# Altium schematic sheet GAP_Power.SchDoc
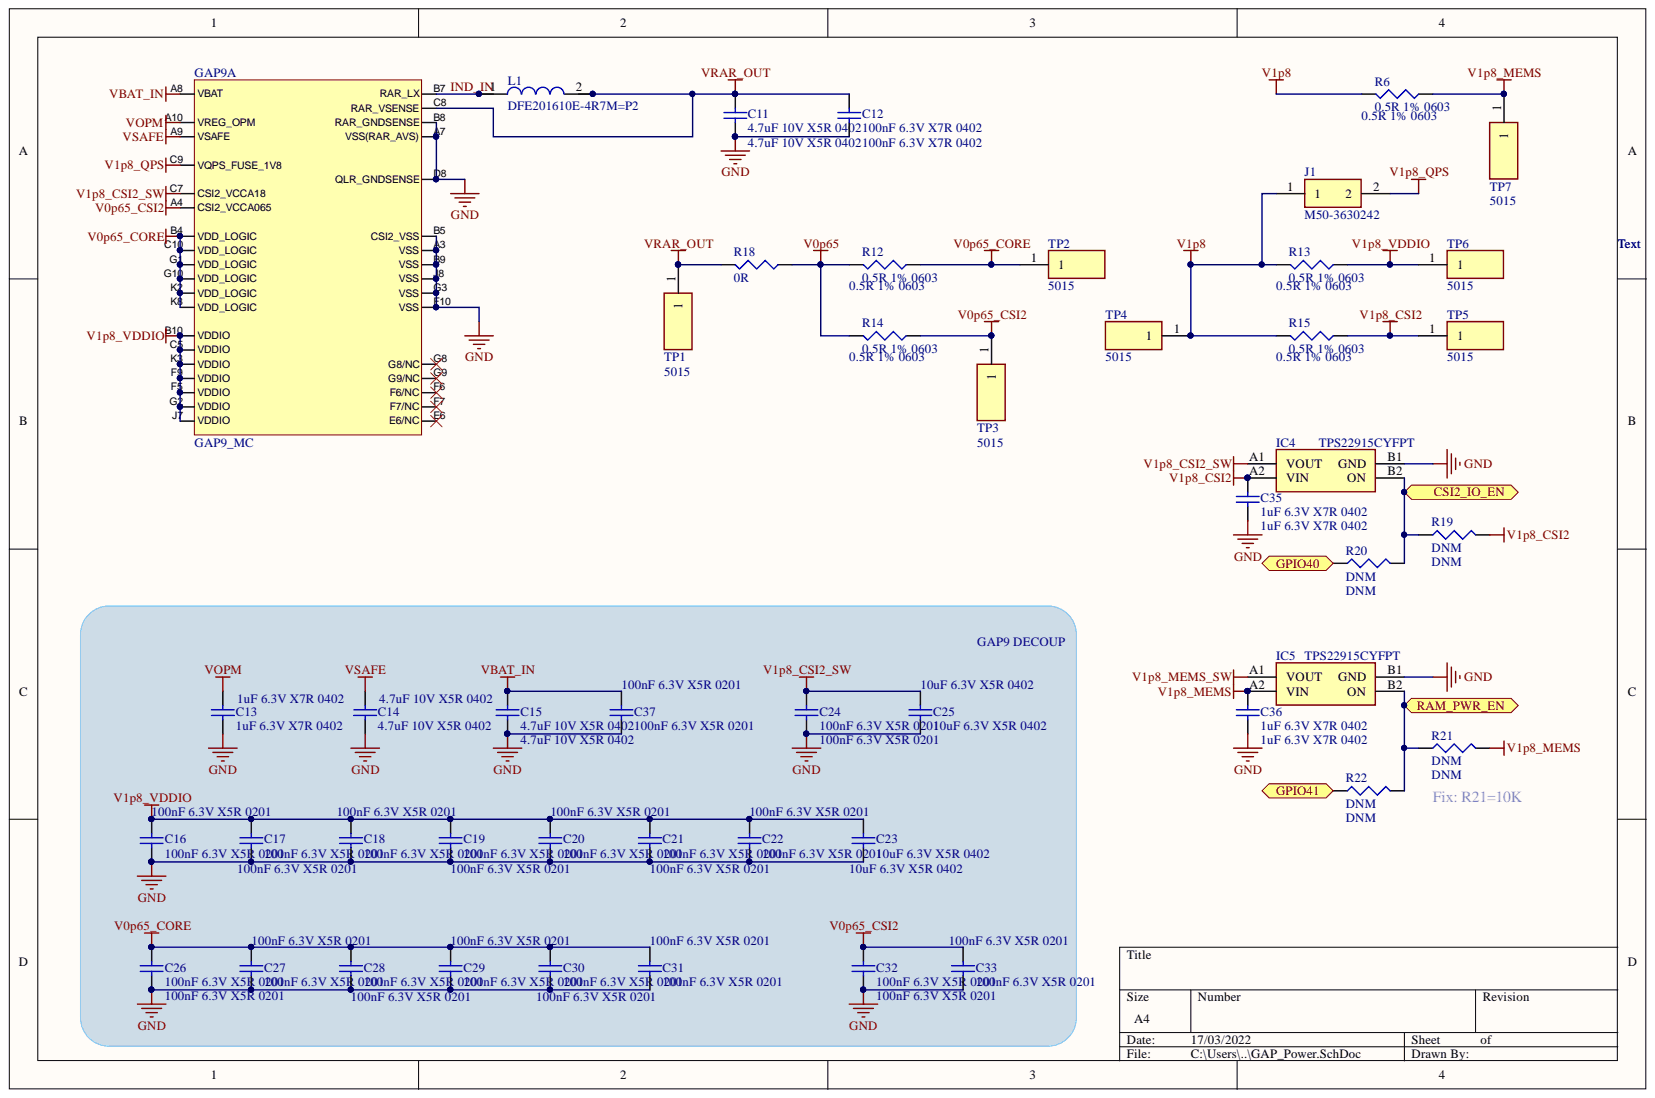
[diagram p0: GAP_Power.SchDoc]

## Parts
C11  4.7uF 10V X5R 0402  [0402]
C12  100nF 6.3V X7R 0402  [0402]
C13  1uF 6.3V X7R 0402  [0402]
C14  4.7uF 10V X5R 0402  [0402]
C15  4.7uF 10V X5R 0402  [0402]
C16  100nF 6.3V X5R 0201  [CAPC0603X33N]
C17  100nF 6.3V X5R 0201  [CAPC0603X33N]
C18  100nF 6.3V X5R 0201  [CAPC0603X33N]
C19  100nF 6.3V X5R 0201  [CAPC0603X33N]
C20  100nF 6.3V X5R 0201  [CAPC0603X33N]
C21  100nF 6.3V X5R 0201  [CAPC0603X33N]
C22  100nF 6.3V X5R 0201  [CAPC0603X33N]
C23  10uF 6.3V X5R 0402  [0402]
C24  100nF 6.3V X5R 0201  [CAPC0603X33N]
C25  10uF 6.3V X5R 0402  [0402]
C26  100nF 6.3V X5R 0201  [CAPC0603X33N]
C27  100nF 6.3V X5R 0201  [CAPC0603X33N]
C28  100nF 6.3V X5R 0201  [CAPC0603X33N]
C29  100nF 6.3V X5R 0201  [CAPC0603X33N]
C30  100nF 6.3V X5R 0201  [CAPC0603X33N]
C31  100nF 6.3V X5R 0201  [CAPC0603X33N]
C32  100nF 6.3V X5R 0201  [CAPC0603X33N]
C33  100nF 6.3V X5R 0201  [CAPC0603X33N]
C35  1uF 6.3V X7R 0402  [0402]
C36  1uF 6.3V X7R 0402  [0402]
C37  100nF 6.3V X5R 0201  [CAPC0603X33N]
GAP9  GAP9_MC  [GAP9]  (part A)
IC4  TPS22915CYFPT  [BGA4C40P2X2_74X74X50]
IC5  TPS22915CYFPT  [BGA4C40P2X2_74X74X50]
J1  M50-3630242  [M503630242]
L1  DFE201610E-4R7M=P2  [1285ASH1R0MP2]
R6  0.5R 1% 0603  [J1-0603]
R12  0.5R 1% 0603  [J1-0603]
R13  0.5R 1% 0603  [J1-0603]
R14  0.5R 1% 0603  [J1-0603]
R15  0.5R 1% 0603  [J1-0603]
R18  0R  [J1-0603]
R19  DNM  [0402]
R20  DNM  [0402]
R21  DNM  [0402]
R22  DNM  [0402]
TP1  5015  [5015]
TP2  5015  [5015]
TP3  5015  [5015]
TP4  5015  [5015]
TP5  5015  [5015]
TP6  5015  [5015]
TP7  5015  [5015]

## Ports
CSI2_IO_EN, GPIO40, GPIO41, RAM_PWR_EN

## Nets
GND: C11.1 C12.1 C13.1 C14.1 C15.1 C16.1 C17.1 C18.1 C19.1 C20.1 C21.1 C22.1 C23.1 C24.1 C25.1 C26.1 C27.1 C28.1 C29.1 C30.1 C31.1 C32.1 C33.1 C35.1 C36.1 C37.1 GAP9.A3(VSS) GAP9.A7(VSS(RAR_AVS)) GAP9.B5(CSI2_VSS) GAP9.B8(RAR_GNDSENSE) GAP9.B9(VSS) GAP9.D8(QLR_GNDSENSE) GAP9.F10(VSS) GAP9.G3(VSS) GAP9.J8(VSS) IC4.B1(GND) IC5.B1(GND)
V0p65: R12.2 R14.2 R18.1
V0p65_CORE: C26.2 C27.2 C28.2 C29.2 C30.2 C31.2 GAP9.B4(VDD_LOGIC) GAP9.C10(VDD_LOGIC) GAP9.G1(VDD_LOGIC) GAP9.G10(VDD_LOGIC) GAP9.K2(VDD_LOGIC) GAP9.K8(VDD_LOGIC) R12.1 TP2.1
V0p65_CSI2: C32.2 C33.2 GAP9.A4(CSI2_VCCA065) R14.1 TP3.1
V1p8: J1.1 R6.2 R13.2 R15.2 TP4.1
V1p8_CSI2: C35.2 IC4.A2(VIN) R15.1 R19.2 TP5.1
V1p8_CSI2_SW: C24.2 C25.2 GAP9.C7(CSI2_VCCA18) IC4.A1(VOUT)
V1p8_MEMS: C36.2 IC5.A2(VIN) R6.1 R21.2 TP7.1
V1p8_MEMS_SW: IC5.A1(VOUT)
V1p8_QPS: GAP9.C9(VQPS_FUSE_1V8) J1.2
V1p8_VDDIO: C16.2 C17.2 C18.2 C19.2 C20.2 C21.2 C22.2 C23.2 GAP9.B10(VDDIO) GAP9.C5(VDDIO) GAP9.F5(VDDIO) GAP9.F9(VDDIO) GAP9.G2(VDDIO) GAP9.J7(VDDIO) GAP9.K3(VDDIO) R13.1 TP6.1
VBAT_IN: C15.2 C37.2 GAP9.A8(VBAT)
VOPM: C13.2 GAP9.A10(VREG_OPM)
VRAR_OUT: C11.2 C12.2 GAP9.C8(RAR_VSENSE) L1.2 R18.2 TP1.1
VSAFE: C14.2 GAP9.A9(VSAFE)
CSI2_IO_EN: IC4.B2(ON) R19.1 R20.2 ; port CSI2_IO_EN
GPIO40: R20.1 ; port GPIO40
GPIO41: R22.1 ; port GPIO41
IND_IN: GAP9.B7(RAR_LX) L1.1
RAM_PWR_EN: IC5.B2(ON) R21.1 R22.2 ; port RAM_PWR_EN

Not connected: GAP9 E6(E6/NC) F6(F6/NC) F7(F7/NC) G8(G8/NC) G9(G9/NC)

## Library data of the parts
C11-C33 C35-C37 = Cap Semi: Capacitor (Semiconductor SIM Model); Component Kind: Standard; LatestRevisionDate: 29-May-2009; LatestRevisionNote: IPC-7351 Footprint Added.; Library Name: Miscellaneous Devices.IntLib; PackageDescription: Chip Capacitor; PackageReference: C1206; Published: 8-Jun-2000; Signal Integrity: Cap Semi; Simulation: CAP; Publisher: Altium Limited
  C11 C14 C15: Value: 4.7uF 10V X5R 0402
  C12: Value: 100nF 6.3V X7R 0402
  C13 C35 C36: Value: 1uF 6.3V X7R 0402
  C16-C22 C24 C26-C33 C37: Value: 100nF 6.3V X5R 0201
  C23 C25: Value: 10uF 6.3V X5R 0402
IC4 IC5 = TPS22916CLYFPR: Integrated Circuit; Manufacturer_Name: Texas Instruments; Arrow Part Number: TPS22916CLYFPR; Arrow Price/Stock: https://www.arrow.com/en/products/tps22916clyfpr/texas-instruments; Component Kind: Standard; Datasheet Link: http://www.ti.com/lit/gpn/tps22916; Height: 0.5mm; Manufacturer_Part_Number: TPS22916CLYFPR; Mouser Part Number: 595-TPS22916CLYFPR; Mouser Price/Stock: https://www.mouser.co.uk/ProductDetail/Texas-Instruments/TPS22916CLYFPR?qs=1mbolxNpo8e6PmBKjVrQVQ%3D%3D
J1 = M50-3630242: Connector; Manufacturer_Name: Harwin; Component Kind: Standard; Datasheet Link: https://cdn.harwin.com/pdfs/M50-363.pdf; Height: 4.95mm; Manufacturer_Part_Number: M50-3630242; Mouser Part Number: 855-M50-3630242; Mouser Price/Stock: https://www.mouser.co.uk/ProductDetail/Harwin/M50-3630242?qs=%252BdQmOuGyFcGm5KVXDM4yoQ%3D%3D
L1 = DFE201610E-4R7M=P2: Inductor; Manufacturer_Name: Murata Electronics; Arrow Part Number: DFE201610E-4R7M=P2; Arrow Price/Stock: https://www.arrow.com/en/products/dfe201610e-4r7mp2/murata-manufacturing?region=nac; Component Kind: Standard; Datasheet Link: https://psearch.en.murata.com/inductor/product/DFE201610E-4R7M%23.html; Height: 1mm; Manufacturer_Part_Number: DFE201610E-4R7M=P2; Mouser Part Number: 81-DFE201610E-4R7MP2; Mouser Price/Stock: https://www.mouser.co.uk/ProductDetail/Murata-Electronics/DFE201610E-4R7M%3dP2?qs=AQlKX63v8RuIglbQNBhlhg%3D%3D
R6 R12-R15 R18 = Res3: Component Kind: Standard; LatestRevisionDate: 29-May-2009; LatestRevisionNote: IPC-7351 Footprint Added.; Library Name: Miscellaneous Devices.IntLib; PackageDescription: Chip Resistor; PackageReference: J1-0603; Published: 8-Jun-2000; Signal Integrity: Res; Simulation: RESISTOR; Publisher: Altium Limited
  R6 R12-R15: Value: 0.5R 1% 0603
R19-R22 = Res3: Resistor; Component Kind: Standard; LatestRevisionDate: 29-May-2009; LatestRevisionNote: IPC-7351 Footprint Added.; Library Name: Miscellaneous Devices.IntLib; PackageDescription: Chip Resistor; PackageReference: J1-0603; Published: 8-Jun-2000; Signal Integrity: Res; Simulation: RESISTOR; Value: DNM; Publisher: Altium Limited
TP1-TP7 = 5015: Undefined or Miscellaneous; Manufacturer_Name: Keystone Electronics; Arrow Part Number: 5015; Arrow Price/Stock: https://www.arrow.com/en/products/5015/keystone-electronics; Component Kind: Standard; Datasheet Link: http://www.keyelco.com/product-pdf.cfm?p=1353; Height: 1.52mm; Manufacturer_Part_Number: 5015; Mouser Part Number: 534-5015; Mouser Price/Stock: https://www.mouser.co.uk/ProductDetail/Keystone-Electronics/5015?qs=qOqV1E1P08ShW56PtUq2FA%3D%3D
GAP9 = GAP9_MC: Component Kind: Standard; Library Name: GAP9.SchLib
